# Altium schematic sheet Sheet2.SchDoc(Sheet2)
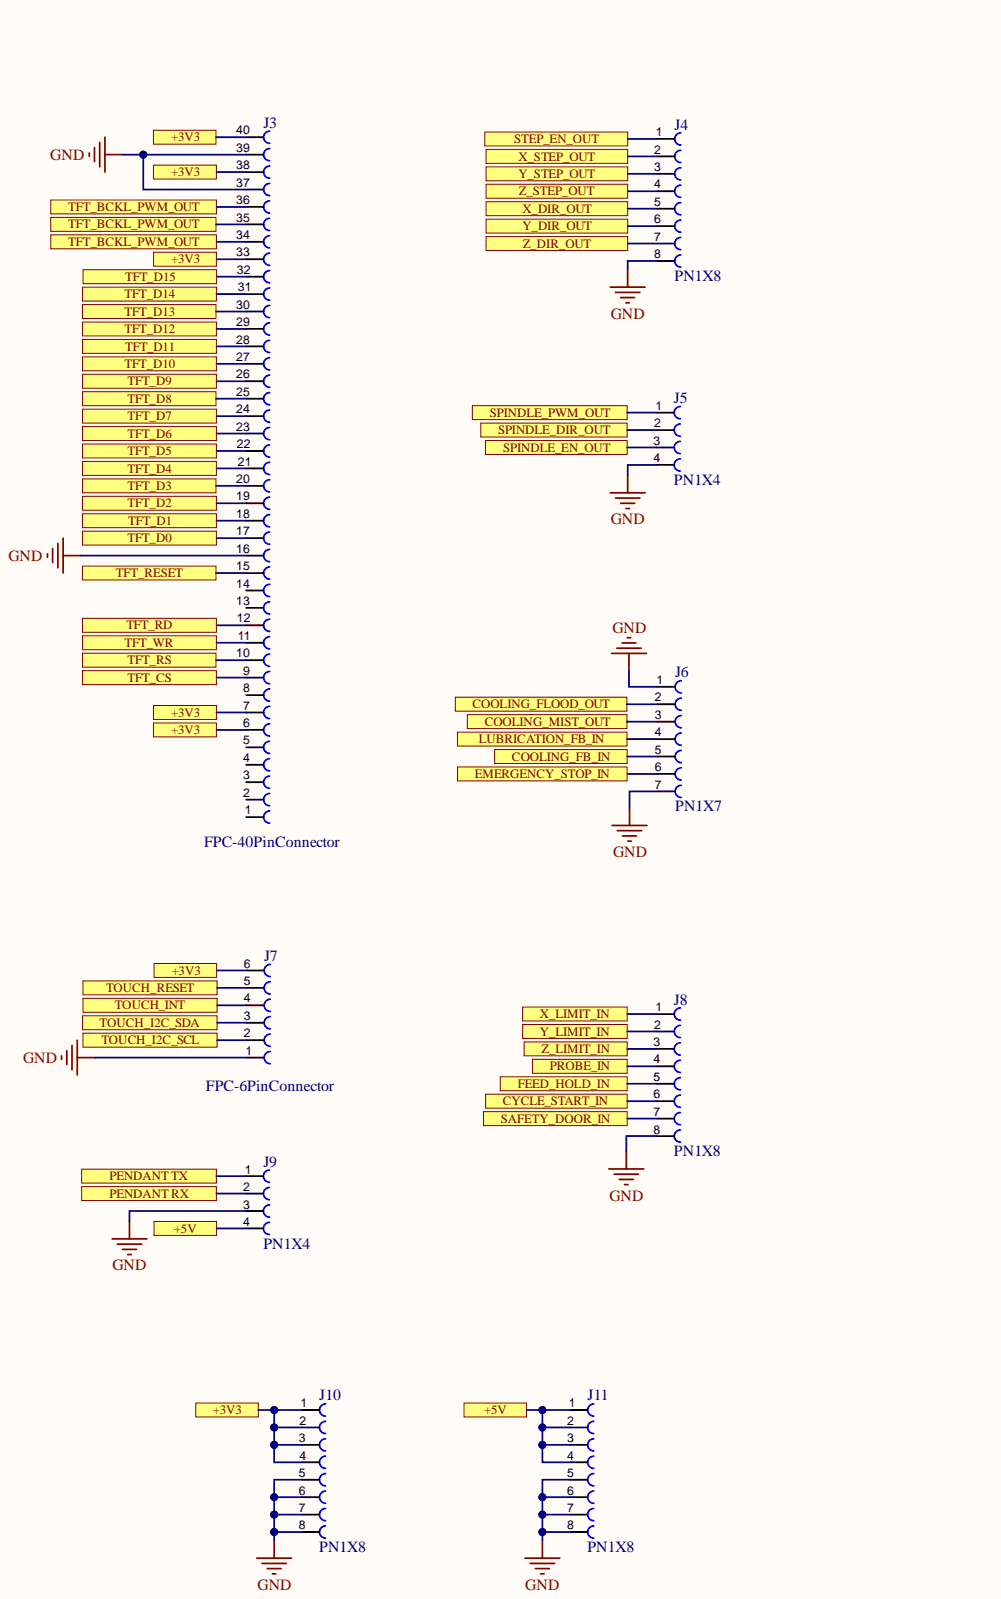
[diagram p0: Sheet2.SchDoc, left]
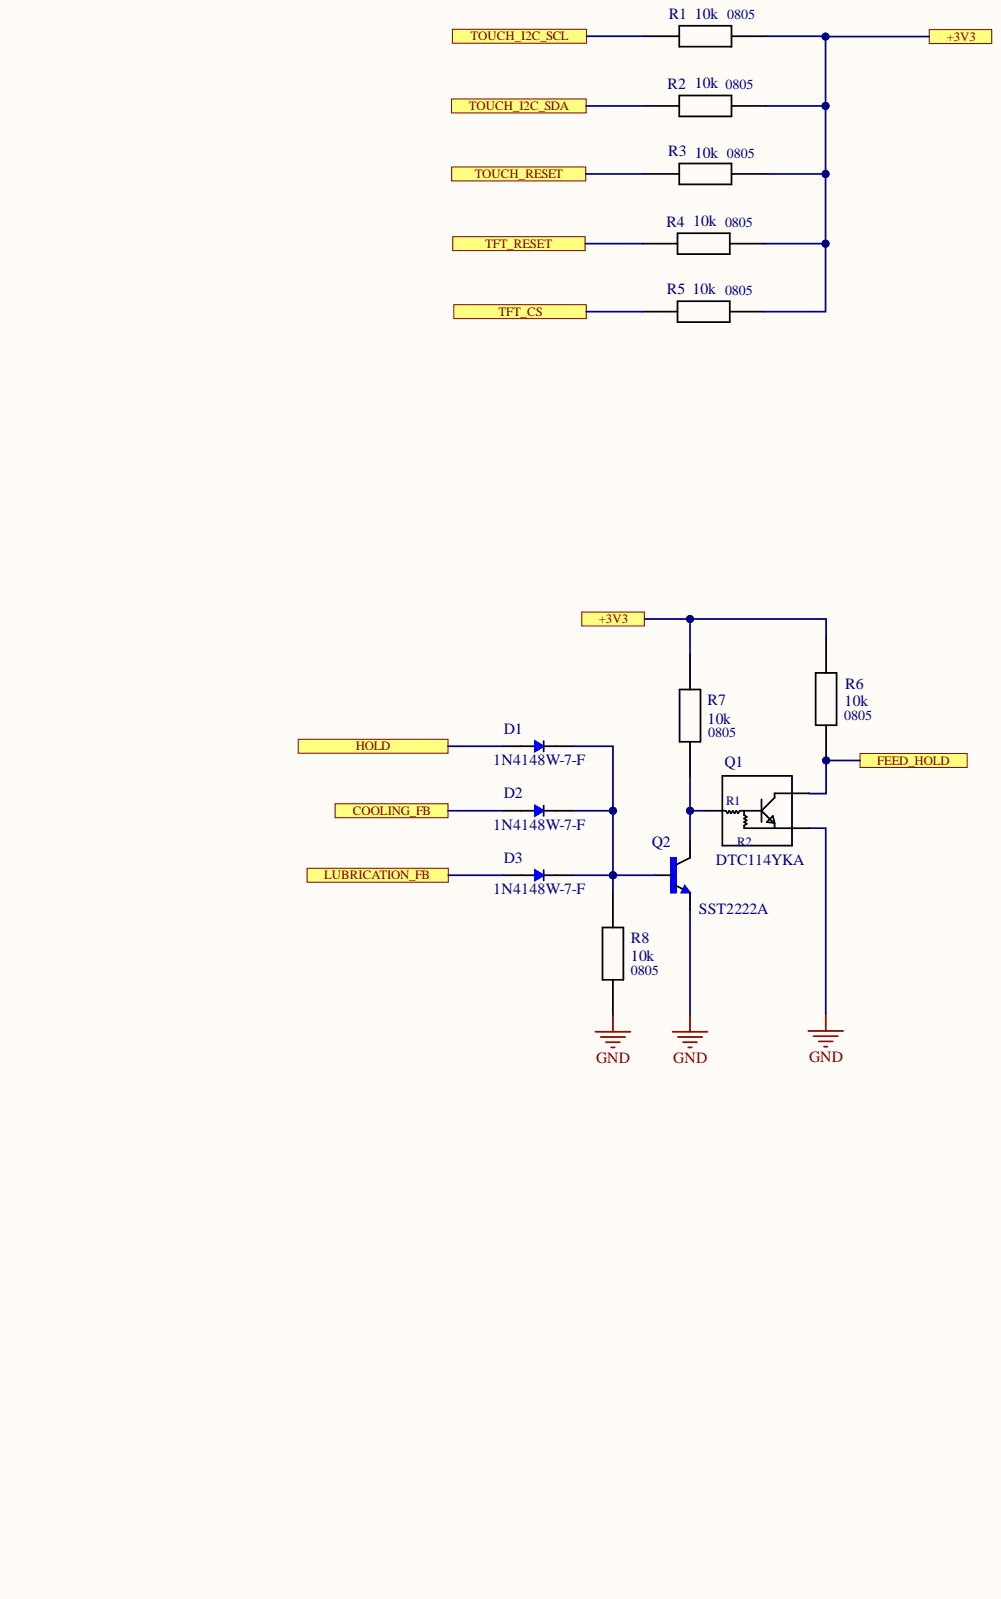
[diagram p1: Sheet2.SchDoc, right]

## Parts
D1  1N4148W-7-F  [SOD123]
D2  1N4148W-7-F  [SOD123]
D3  1N4148W-7-F  [SOD123]
J3  FPC-40PinConnector  [687140149022]
J4  PN1X8  [PN1X8]
J5  PN1X4  [PN1X4]
J6  PN1X7  [PN1X7]
J7  FPC-6PinConnector  [687106149022]
J8  PN1X8  [PN1X8]
J9  PN1X4  [PN1X4]
J10  PN1X8  [PN1X8]
J11  PN1X8  [PN1X8]
Q1  DTC114YKA  [SOT-346 (SC59) Black]
Q2  SST2222A  [SOT-23-3]
R1  10k  Case: 0805  [SMD 0805]
R2  10k  Case: 0805  [SMD 0805]
R3  10k  Case: 0805  [SMD 0805]
R4  10k  Case: 0805  [SMD 0805]
R5  10k  Case: 0805  [SMD 0805]
R6  10k  Case: 0805  [SMD 0805]
R7  10k  Case: 0805  [SMD 0805]
R8  10k  Case: 0805  [SMD 0805]

## Ports
+3V3, +5V, COOLING_FB, COOLING_FB_IN, COOLING_FLOOD_OUT, COOLING_MIST_OUT, CYCLE_START_IN, EMERGENCY_STOP_IN, FEED_HOLD, FEED_HOLD_IN, HOLD, LUBRICATION_FB, LUBRICATION_FB_IN, PENDANT RX, PENDANT TX, PROBE_IN, SAFETY_DOOR_IN, SPINDLE_DIR_OUT, SPINDLE_EN_OUT, SPINDLE_PWM_OUT, STEP_EN_OUT, TFT_BCKL_PWM_OUT, TFT_CS, TFT_D0, TFT_D1, TFT_D2, TFT_D3, TFT_D4, TFT_D5, TFT_D6, TFT_D7, TFT_D8, TFT_D9, TFT_D10, TFT_D11, TFT_D12, TFT_D13, TFT_D14, TFT_D15, TFT_RD, TFT_RESET, TFT_RS, TFT_WR, TOUCH_I2C_SCL, TOUCH_I2C_SDA, TOUCH_INT, TOUCH_RESET, X_DIR_OUT, X_LIMIT_IN, X_STEP_OUT, Y_DIR_OUT, Y_LIMIT_IN, Y_STEP_OUT, Z_DIR_OUT, Z_LIMIT_IN, Z_STEP_OUT

## Nets
GND: J3.16 J3.37 J3.39 J4.8 J5.4 J6.1 J6.7 J7.1 J8.8 J9.3 J10.5 J10.6 J10.7 J10.8 J11.5 J11.6 J11.7 J11.8 Q1.2 Q2.2 R8.2
+3V3: J3.6 J3.7 J3.33 J3.38 J3.40 J7.6 J10.1 J10.2 J10.3 J10.4 R1.1 R2.2 R3.2 R4.1 R5.1 R6.1 R7.1 ; port +3V3
+5V: J9.4 J11.1 J11.2 J11.3 J11.4 ; port +5V
COOLING_FB: D2.A ; port COOLING_FB
COOLING_FB_IN: J6.5 ; port COOLING_FB_IN
COOLING_FLOOD_OUT: J6.2 ; port COOLING_FLOOD_OUT
COOLING_MIST_OUT: J6.3 ; port COOLING_MIST_OUT
CYCLE_START_IN: J8.6 ; port CYCLE_START_IN
EMERGENCY_STOP_IN: J6.6 ; port EMERGENCY_STOP_IN
FEED_HOLD: Q1.3 R6.2 ; port FEED_HOLD
FEED_HOLD_IN: J8.5 ; port FEED_HOLD_IN
HOLD: D1.A ; port HOLD
LUBRICATION_FB: D3.A ; port LUBRICATION_FB
LUBRICATION_FB_IN: J6.4 ; port LUBRICATION_FB_IN
PENDANT RX: J9.2 ; port PENDANT RX
PENDANT TX: J9.1 ; port PENDANT TX
PROBE_IN: J8.4 ; port PROBE_IN
SAFETY_DOOR_IN: J8.7 ; port SAFETY_DOOR_IN
SPINDLE_DIR_OUT: J5.2 ; port SPINDLE_DIR_OUT
SPINDLE_EN_OUT: J5.3 ; port SPINDLE_EN_OUT
SPINDLE_PWM_OUT: J5.1 ; port SPINDLE_PWM_OUT
STEP_EN_OUT: J4.1 ; port STEP_EN_OUT
TFT_BCKL_PWM_OUT: J3.34 J3.35 J3.36 ; port TFT_BCKL_PWM_OUT
TFT_CS: J3.9 R5.2 ; port TFT_CS
TFT_D0: J3.17 ; port TFT_D0
TFT_D1: J3.18 ; port TFT_D1
TFT_D2: J3.19 ; port TFT_D2
TFT_D3: J3.20 ; port TFT_D3
TFT_D4: J3.21 ; port TFT_D4
TFT_D5: J3.22 ; port TFT_D5
TFT_D6: J3.23 ; port TFT_D6
TFT_D7: J3.24 ; port TFT_D7
TFT_D8: J3.25 ; port TFT_D8
TFT_D9: J3.26 ; port TFT_D9
TFT_D10: J3.27 ; port TFT_D10
TFT_D11: J3.28 ; port TFT_D11
TFT_D12: J3.29 ; port TFT_D12
TFT_D13: J3.30 ; port TFT_D13
TFT_D14: J3.31 ; port TFT_D14
TFT_D15: J3.32 ; port TFT_D15
TFT_RD: J3.12 ; port TFT_RD
TFT_RESET: J3.15 R4.2 ; port TFT_RESET
TFT_RS: J3.10 ; port TFT_RS
TFT_WR: J3.11 ; port TFT_WR
TOUCH_I2C_SCL: J7.2 R1.2 ; port TOUCH_I2C_SCL
TOUCH_I2C_SDA: J7.3 R2.1 ; port TOUCH_I2C_SDA
TOUCH_INT: J7.4 ; port TOUCH_INT
TOUCH_RESET: J7.5 R3.1 ; port TOUCH_RESET
X_DIR_OUT: J4.5 ; port X_DIR_OUT
X_LIMIT_IN: J8.1 ; port X_LIMIT_IN
X_STEP_OUT: J4.2 ; port X_STEP_OUT
Y_DIR_OUT: J4.6 ; port Y_DIR_OUT
Y_LIMIT_IN: J8.2 ; port Y_LIMIT_IN
Y_STEP_OUT: J4.3 ; port Y_STEP_OUT
Z_DIR_OUT: J4.7 ; port Z_DIR_OUT
Z_LIMIT_IN: J8.3 ; port Z_LIMIT_IN
Z_STEP_OUT: J4.4 ; port Z_STEP_OUT
NetD1_C: D1.C D2.C D3.C Q2.1 R8.1
NetQ1_1: Q1.1 Q2.3 R7.2

Not connected: J3 1 2 3 4 5 8 13 14

## Library data of the parts
D1-D3 = 1N4148W-7-F: Diode Standard 100V 300mA _DC_ Surface Mount SOD-123; MF: DIODES / ZETEX; Case: SOD-123 Diodes Inc.; Component Kind: Standard; HelpURL: https://store.comet.bg/Catalogue/Product/9613/; Library Name: CAN_Library.IntLib; Model: 1N4148W-7-F; Value: 1N4148W-7-F
J3 = FPC-40PinConnector: 40 Position FFC, FPC Connector Contacts, Bottom 0.020 (0.50mm) Surface Mount, Right Angle; MF: Wurth Electronics; Availability: In Stock; Check_prices: https://www.snapeda.com/parts/687140149022/Wurth+Electronics+Inc./view-part/?ref=eda; Component Kind: Standard; Library Name: CAN_Library.IntLib; MP: 687140149022; Package: None; Price: None; Purchase-URL: https://pricing.snapeda.com/search/part/687140149022/?ref=eda; SnapEDA_Link: https://www.snapeda.com/parts/687140149022/Wurth+Electronics+Inc./view-part/?ref=snap; Value: FPC-40PinConnector
J4 J8 J10 J11 = PN1X8: Single Row / Straight / Without Shroud Female header Female 8 | WÃ¼rth Elektronik 61300711821 @ Pin header, socket _Si...; Availability: Unavailable; Component Kind: Standard; Library Name: CAN_Library.IntLib; MF: WÃ¼rth Elektronik; MP: 61300711821; Package: None; Price: None; SnapEDA_Link: https://www.snapeda.com/parts/61300711821/W%C3%BCrth%20Elektronik/view-part/2704590/?ref=snap; Value: PN1X8
J5 J9 = PN1X4: Single Row / Straight / Without Shroud Female header Female 4 @ Pin header, socket _Si...; MF: WÃ¼rth Elektronik; MP: 61300711821; Availability: Unavailable; Component Kind: Standard; Library Name: CAN_Library.IntLib; Package: None; Price: None; SnapEDA_Link: https://www.snapeda.com/parts/61300711821/W%C3%BCrth%20Elektronik/view-part/2704590/?ref=snap; Value: PN1X4
J6 = PN1X7: Single Row / Straight / Without Shroud Female header Female 7 | WÃ¼rth Elektronik 61300711821 @ Pin header, socket _Si...; Case: 0,8 mm; Component Kind: Standard; Library Name: CAN_Library.IntLib; Value: PN1X7
J7 = FPC-6PinConnector: 6 Position FFC, FPC Connector Contacts, Bottom 0.020 (0.50mm) Surface Mount, Right Angle; MF: WÃ¼rth Elektronik; Availability: In Stock; Check_prices: https://www.snapeda.com/parts/687106149022/Wurth+Elektronik/view-part/?ref=eda; Component Kind: Standard; Library Name: CAN_Library.IntLib; MP: 687106149022; Package: None; Price: None; Purchase-URL: https://pricing.snapeda.com/search/part/687106149022/?ref=eda; SnapEDA_Link: https://www.snapeda.com/parts/687106149022/Wurth+Elektronik/view-part/?ref=snap; Value: FPC-6PinConnector
Q1 = DTC114YKA: NPN Digital 50V 100mA 200mW  R1=10kOm R2=47kOm; Manufacturer: ROHM Semiconductor; Case: SOT-346 (SC59); Component Kind: Standard; HalpURL: https://store.comet.bg/Catalogue/Product/23715/; Library Name: CAN_Library.IntLib; Value: DTC114YKA
Q2 = SST2222A: Transistor NPN 40V 600mA 300MHz 350mW; Manufacturer: ROHM Semiconductor; Case: SOT-23-3 SST3; Component Kind: Standard; HelpURL: https://store.comet.bg/Catalogue/Product/23715/; Library Name: CAN_Library.IntLib; Value: SST2222A
R1-R8 = Resistor_1: RES; Component Kind: Standard; HelpURL: https://store.comet.bg/Catalogue/RES/Resistors/#eyJpcHAiOiIxMDAiLCJtIjoiIn0%3D; Library Name: CAN_Library.IntLib; Value: 10k
